# Altium schematic sheet Manettino_1.SchDoc(Manettino_1)
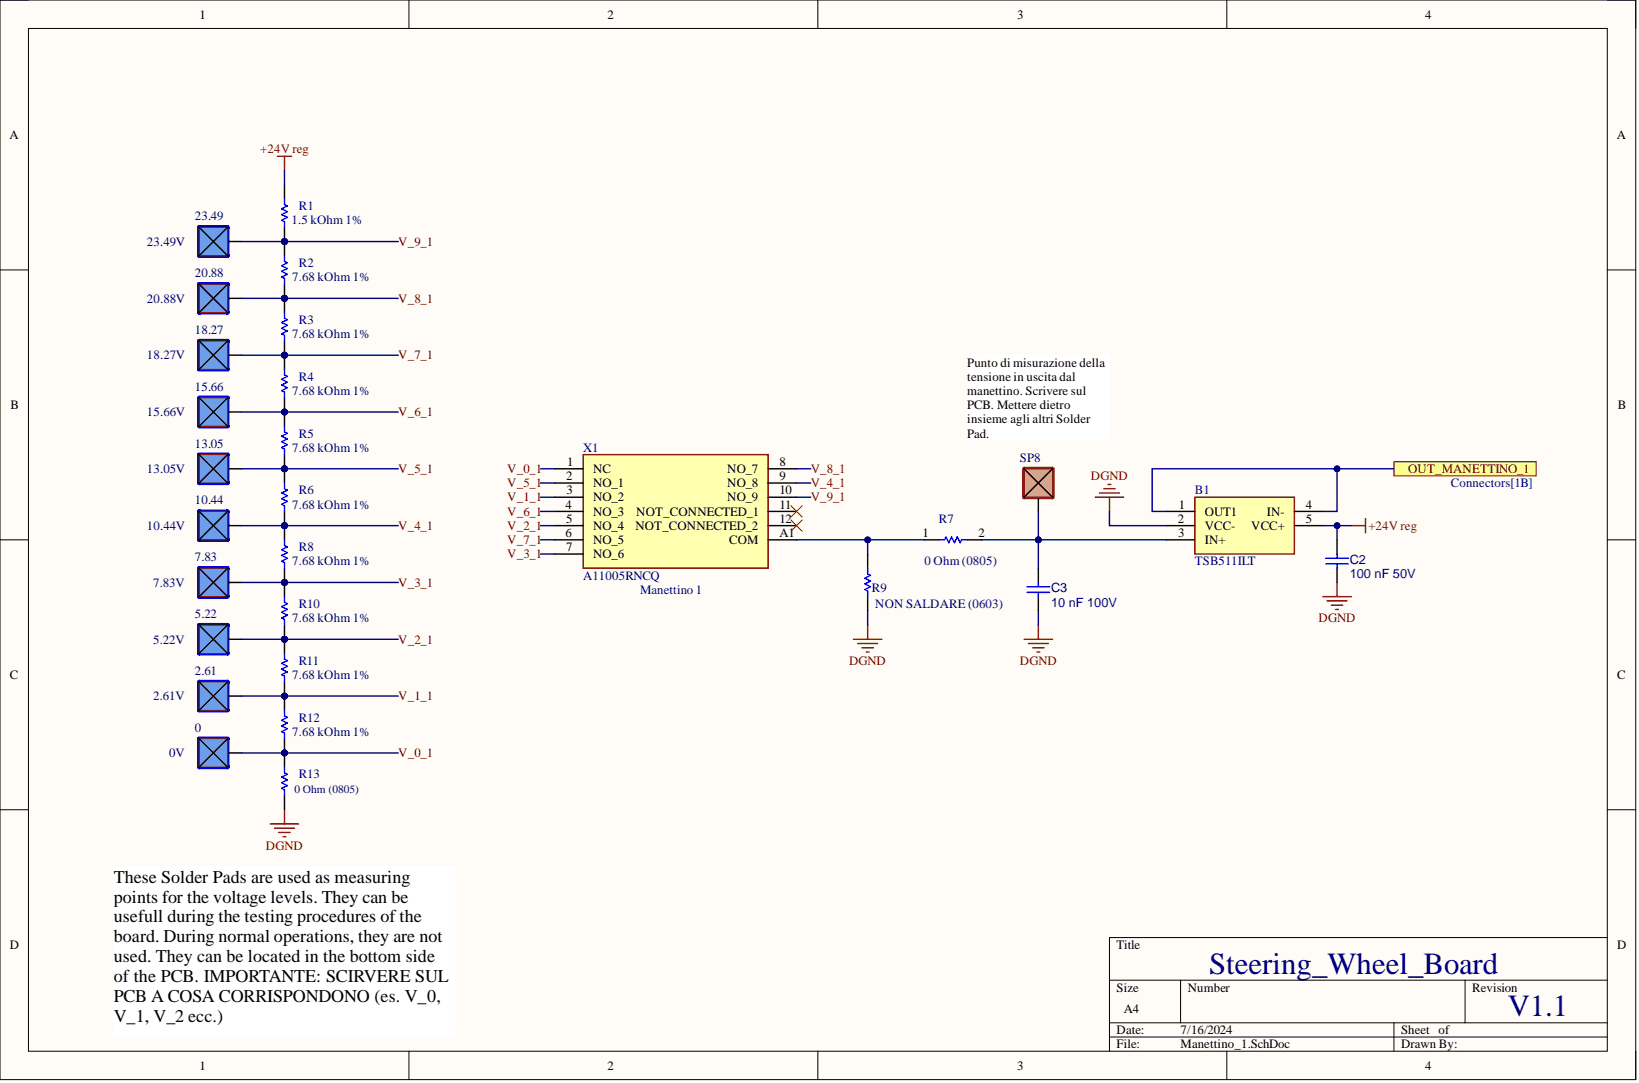
[diagram p0: Manettino_1.SchDoc]

## Parts
0  Solder_Pad_3mm  (value not drawn)
2.61  Solder_Pad_3mm  (value not drawn)
5.22  Solder_Pad_3mm  (value not drawn)
7.83  Solder_Pad_3mm  (value not drawn)
10.44  Solder_Pad_3mm  (value not drawn)
13.05  Solder_Pad_3mm  (value not drawn)
15.66  Solder_Pad_3mm  (value not drawn)
18.27  Solder_Pad_3mm  (value not drawn)
20.88  Solder_Pad_3mm  (value not drawn)
23.49  Solder_Pad_3mm  (value not drawn)
B1  TSB511ILT
C2  100 nF 50V
C3  10 nF 100V
R1  1.5 kOhm 1%
R2  7.68 kOhm 1%
R3  7.68 kOhm 1%
R4  7.68 kOhm 1%
R5  7.68 kOhm 1%
R6  7.68 kOhm 1%
R7  0 Ohm (0805)
R8  7.68 kOhm 1%
R9  NON SALDARE (0603)
R10  7.68 kOhm 1%
R11  7.68 kOhm 1%
R12  7.68 kOhm 1%
R13  0 Ohm (0805)
SP8  Solder_Pad_5mm  (value not drawn)
X1  A11005RNCQ

## Ports
OUT_MANETTINO_1

## Nets
+24V reg: B1.5(VCC+) C2.2 R1.2
DGND: B1.2(VCC-) C2.1 C3.1 R9.1 R13.1
OUT_MANETTINO_1: B1.1(OUT1) B1.4(IN-) ; port OUT_MANETTINO_1
V_0_1: 0.1 R12.1 R13.2 X1.1(NC)
V_1_1: 2.61.1 R11.1 R12.2 X1.3(NO_2)
V_2_1: 5.22.1 R10.1 R11.2 X1.5(NO_4)
V_3_1: 7.83.1 R8.1 R10.2 X1.7(NO_6)
V_4_1: 10.44.1 R6.1 R8.2 X1.9(NO_8)
V_5_1: 13.05.1 R5.1 R6.2 X1.2(NO_1)
V_6_1: 15.66.1 R4.1 R5.2 X1.4(NO_3)
V_7_1: 18.27.1 R3.1 R4.2 X1.6(NO_5)
V_8_1: 20.88.1 R2.1 R3.2 X1.8(NO_7)
V_9_1: 23.49.1 R1.1 R2.2 X1.10(NO_9)
NetB1_3: B1.3(IN+) C3.2 R7.2 SP8.1
NetR7_1: R7.1 R9.2 X1.A1(COM)

Not connected: X1 11(NOT_CONNECTED_1) 12(NOT_CONNECTED_2)

## Library data of the parts
0 2.61 5.22 7.83 10.44 13.05 15.66 18.27 20.88 23.49 = CMP-00001-2: Component Kind: Standard; Description: Solder_Pad_3mm; Library Name: EMEA- Squadra Corse PoliTO-  Politecnico di Torino
B1 = CMP-002-000050-5: Rail-to-rail inputs and outputs, 36 V, 6 MHz op-amps; Manufacturer_Name: STMicroelectronics; Geometry.Height: 1.45mm; Component Kind: Standard; Datasheet Link: https://www.st.com/en/amplifiers-and-comparators/tsb511.html; Design Item ID: TSB511ILT; Manufacturer_Part_Number: TSB511ILT; Source: TSB511ILT.SchLib; Library Name: EMEA- Squadra Corse PoliTO-  Politecnico di Torino
C2 = CMP-001-00085-2: VJ0805Y104MXATW1BC; Tech: SMD; Component Kind: Standard; ComponentLink1Description: Website; ComponentLink1URL: https://www.mouser.it/ProductDetail/KYOCERA-AVX/08055C104KAT4A?qs=2ujN4bDBNE8aFT7CFyBStg%3D%3D; Package: 0805; Library Name: EMEA- Squadra Corse PoliTO-  Politecnico di Torino
C3 = CMP-001-00085-2: CC0805MRX7R9BB103; Tech: SMD; Component Kind: Standard; ComponentLink1Description: Website; ComponentLink1URL: https://www.mouser.it/ProductDetail/Vishay-Vitramon/VJ0805H103KXBBC31?qs=ak4kh1ihuH4MS2NjAUlroQ%3D%3D; Package: 0805; Library Name: EMEA- Squadra Corse PoliTO-  Politecnico di Torino
R1 = CMP-009-00179-4: RC0603FR-131K5L; Tech: SMD; Component Kind: Standard; ComponentLink1Description: Website; ComponentLink1URL: https://www.mouser.it/ProductDetail/Vishay-Dale/CRCW06031K50FKEAC?qs=E3Y5ESvWgWNew%2F2IId%252BHLA%3D%3D; Package: 0603; Library Name: EMEA- Squadra Corse PoliTO-  Politecnico di Torino
R2-R6 R8 R10-R12 = CMP-009-00179-4: RC0603FR-077K68L; Tech: SMD; Component Kind: Standard; ComponentLink1Description: Website; ComponentLink1URL: https://www.mouser.it/ProductDetail/Vishay-Dale/CRCW06037K68FKEA?qs=sGAEpiMZZMtlubZbdhIBIB%252BQki0fDm%2FcnHBRcles%2F74%3D; Package: 0603; Library Name: EMEA- Squadra Corse PoliTO-  Politecnico di Torino
R7 R13 = CMP-009-00177-13: AC0805FR-070RL; Tech: SMD; Component Kind: Standard; ComponentLink1Description: Website; Package: 0805; Library Name: EMEA- Squadra Corse PoliTO-  Politecnico di Torino
  R13: ComponentLink1URL: https://www.mouser.it/ProductDetail/YAGEO/AC0805FR-070RL?qs=ygRr%2FtkhteskusLKLf%2FBRw%3D%3D
R9 = CMP-009-00179-4: Footprint 0603; Tech: SMD; Component Kind: Standard; ComponentLink1Description: Website; Package: 0603; Library Name: EMEA- Squadra Corse PoliTO-  Politecnico di Torino
SP8 = CMP-00000-21: Solder Pad 5mm; Component Kind: Standard; Library Name: EMEA- Squadra Corse PoliTO-  Politecnico di Torino
X1 = CMP-002-000054-2: Rotary Switches Rotary; Manufacturer_Name: C & K COMPONENTS; Geometry.Height: 58.08mm; Component Kind: Standard; Datasheet Link: https://www.ckswitches.com/media/1349/arotary.pdf; Design Item ID: A11005RNCQ; Manufacturer_Part_Number: A11005RNCQ; Mouser Part Number: 611-A11005RNCQ; Mouser Price/Stock: https://www.mouser.co.uk/ProductDetail/CK/A11005RNCQ?qs=h7H1bHRqGz8p8cCPLkhHpg%3D%3D; Source: A11005RNCQ.SchLib; Library Name: EMEA- Squadra Corse PoliTO-  Politecnico di Torino
